# Altium schematic sheet [5]Motor_Driver.SchDoc
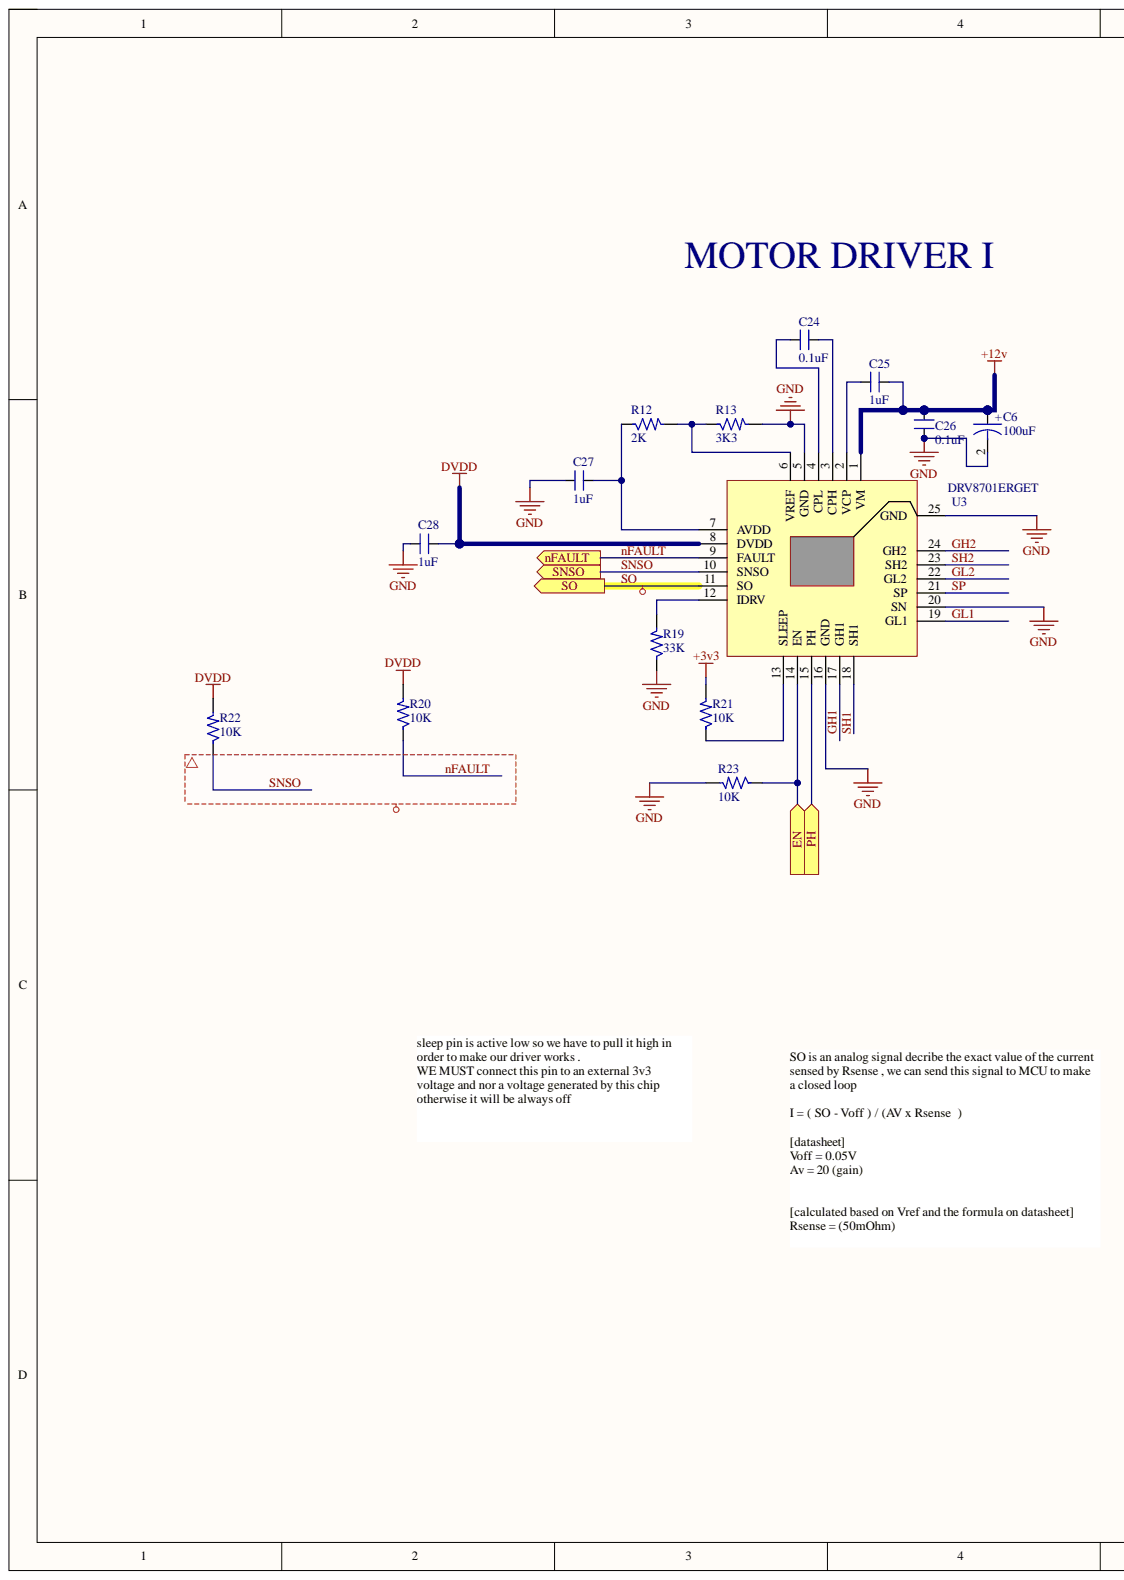
[diagram p0: [5]Motor_Driver.SchDoc, left]
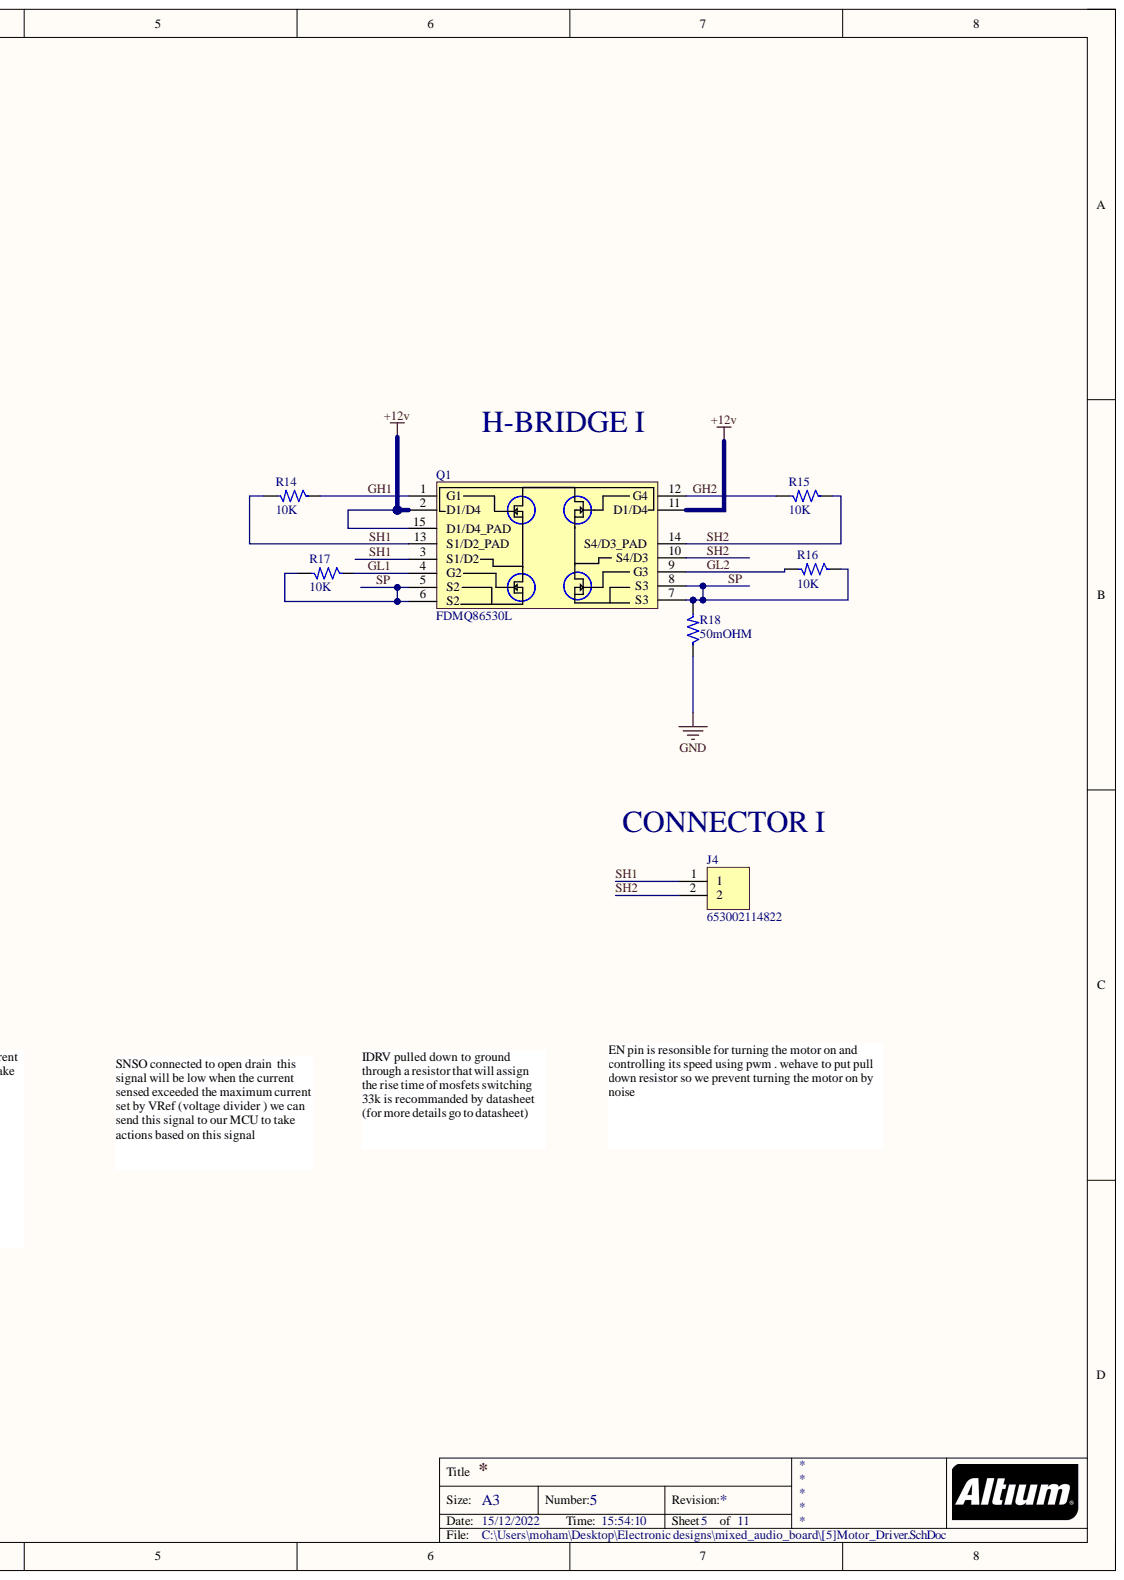
[diagram p1: [5]Motor_Driver.SchDoc, right]

## Parts
C6  100uF  [ACAP_P305_D393_H393_RAD]
C24  0.1uF  [CAP_0805]
C25  1uF  [CAP_0805]
C26  0.1uF  [CAP_0805]
C27  1uF  [CAP_0805]
C28  1uF  [CAP_0805]
J4  653002114822  [CON2_50_653002114822_TH_ST]
Q1  FDMQ86530L  [DFN5045-12_33_212_27X15_SMD_1]
R12  2K  [RES_0805]
R13  3K3  [RES_0603]
R14  10K  [RES_0805]
R15  10K  [RES_0805]
R16  10K  [RES_0805]
R17  10K  [RES_0805]
R18  50mOHM  [RES_2512]
R19  33K  [RES_0805]
R20  10K  [RES_0805]
R21  10K  [RES_0805]
R22  10K  [RES_0805]
R23  10K  [RES_0805]
U3  DRV8701ERGET  [VFQFN24_19.6_196_12X32_SMD_1]

## Ports
EN, nFAULT, PH, SNSO, SO

## Nets
+3v3: R21.2
+12v: C6.1 C25.2 C26.2 Q1.2(D1/D4) Q1.11(D1/D4) Q1.15(D1/D4_PAD) U3.1(VM)
DVDD: C28.2 R20.2 R22.2 U3.8(DVDD)
GND: C6.2 C26.1 C27.1 C28.1 R13.2 R18.1 R19.1 R23.2 U3.5(GND) U3.16(GND) U3.20(SN) U3.25(GND)
EN: R23.1 U3.14(EN) ; port EN
GH1: Q1.1(G1) R14.1 U3.17(GH1)
GH2: Q1.12(G4) R15.2 U3.24(GH2)
GL1: Q1.4(G2) R17.1 U3.19(GL1)
GL2: Q1.9(G3) R16.2 U3.22(GL2)
nFAULT: R20.1 U3.9(FAULT) ; port nFAULT
PH: U3.15(PH) ; port PH
SH1: J4.1 Q1.3(S1/D2) Q1.13(S1/D2_PAD) R14.2 U3.18(SH1)
SH2: J4.2 Q1.10(S4/D3) Q1.14(S4/D3_PAD) R15.1 U3.23(SH2)
SNSO: R22.1 U3.10(SNSO) ; port SNSO
SO: U3.11(SO) ; port SO
SP: Q1.5(S2) Q1.6(S2) Q1.7(S3) Q1.8(S3) R16.1 R17.2 R18.2 U3.21(SP)
NetC24_1: C24.1 U3.4(CPL)
NetC24_2: C24.2 U3.3(CPH)
NetC25_1: C25.1 U3.2(VCP)
NetC27_2: C27.2 R12.1 U3.7(AVDD)
NetR12_2: R12.2 R13.1 U3.6(VREF)
NetR19_2: R19.2 U3.12(IDRV)
NetR21_1: R21.1 U3.13(SLEEP)

## Library data of the parts
C6 = CAP-P_100uF-50V-20%-RAD: 100µF 50V Aluminum Polymer Capacitor Radial, Can - SMD 28mOhm 4000 Hrs @ 125°C; Alternate Part Number-1: VEU101M1HTR-1010; Manufacturer: Nichicon; Manufacturer Part Number: GYA1H101MCQ1GS; Case/Package: Radial-SMD; Component Kind: Standard; Library Name: ESTEEMPCBLIB.IntLib; Supplier Part Number-1: GYA1H101MCQ1GS; Supplier-1: SP ROAD
C24 C26 = CAP_0.1uF-50V-5%-0805: 0.1µF ±5% 50V Ceramic Capacitor X7R 0805 (2012 Metric); Alternate Part Number-1: 0805B104M500; Manufacturer: AVX Corporation; Manufacturer Part Number: 08055C104JAT4A; Case/Package: 0805; Component Kind: Standard; Library Name: ESTEEMPCBLIB.IntLib; Supplier Part Number-1: 0805B104J500CT; Supplier-1: SIRICOM
C25 C27 C28 = CAP_1uF-50V-5%-0805: 1µF ±5% 50V Ceramic Capacitor X7R 0805 (2012 Metric); Alternate Part Number-1: 0805F105M500NT; Manufacturer: Samsung; Manufacturer Part Number: CC0805JKX7R9BB105; Case/Package: 0805; Component Kind: Standard; Library Name: ESTEEMPCBLIB.IntLib; Supplier Part Number-1: CL21B105KBFNNNE; Supplier-1: SIRICOM
J4 = CON-PLG_2X1-50-ST-TH: 2 Pins, 1 Row 1.25mm Pitch, Straight Connector, male; Alternate Part Number-1: NA; Crimp Part Number: 653153128130; Manufacturer: JST; Mating Part Number: 653002113322; Case/Package: TH-CON; Component Kind: Standard; Library Name: ESTEEMPCBLIB.IntLib; Manufacturer Part Number: 653002114822; Supplier Part Number-1: 653002114822; Supplier-1: WURTH
Q1 = MOSFET-N_60V-8A-V-DFN5045-12: Mosfet Array 4 N-Channel 8A (Ta) Surface Mount V-DFN5045-12; Alternate Part Number-1: NA; Manufacturer: ON Semiconductor; Case/Package: DFN5045-12; Component Kind: Standard; Library Name: ESTEEMPCBLIB.IntLib; Manufacturer Part Number: FDMQ86530L; Supplier Part Number-1: FDMQ86530L; Supplier-1: Arrow
R12 = RES_2K-0.125W-1%-0805: 2 kOhms ±1% 0.125W, 1/8W Chip Resistor 0805 (2012 Metric) Moisture Resistant Thick Film; Alternate Part Number-1: NA; Manufacturer: TE Connectivity; Manufacturer Part Number: RC0805FR-072KL; Case/Package: 0805; Component Kind: Standard; Library Name: ESTEEMPCBLIB.IntLib; Supplier Part Number-1: WR08X2001FTL; Supplier-1: SIRICOM
R13 = RES_3.3K-0.1W-1%-0603: 3.3 kOhms ±1% 0.1W, 1/10W Chip Resistor 0603 (1608 Metric) Automotive AEC-Q200 Thick Film; Alternate Part Number-1: 0603WAF3301T5E; Manufacturer: Wilson; Manufacturer Part Number: WR06X3301FTL; Case/Package: 0603; Component Kind: Standard; Library Name: ESTEEMPCBLIB.IntLib; Supplier Part Number-1: WR06X3301FTL; Supplier-1: SIRICOM
R14-R17 R20-R23 = RES_10K-0.125W-1%-0805: 10 kOhms ±1% 0.125W, 1/8W Chip Resistor 0805 (2012 Metric) Automotive AEC-Q200 Thick Film; Alternate Part Number-1: WR08X1002FTL; Manufacturer: CTS; Case/Package: 0805; Component Kind: Standard; Library Name: ESTEEMPCBLIB.IntLib; Manufacturer Part Number: WR08X1002FTL; Supplier Part Number-1: WR08X1002FTL; Supplier-1: SIRICOM
R18 = RES_50mOhm-2W-1%-2512: 50 mOhms ±1% 2W Chip Resistor 2512 (6432 Metric) Automotive AEC-Q200, Current Sense, Moisture Resistant Metal Element; Alternate Part Number-1: PMR100HZPFU5L00; Manufacturer: Yageo; Manufacturer Part Number: PA2512FKF7W0R05E; Case/Package: 2512; Component Kind: Standard; Library Name: ESTEEMPCBLIB.IntLib; Supplier Part Number-1: LR2512-22R060F1; Supplier-1: SIRICOM
R19 = RES_33K-0.125W-1%-0805: Thick Film Resistors - SMD 33KOhms 1/8W 150V33 kOhms ±1% 0.125W, 1/8W Chip Resistor 0805 (2012 Metric) Thick Film; Alternate Part Number-1: NA; Manufacturer: Vishay BCcomponents; Manufacturer Part Number: CRG0805F33K; Case/Package: 0805; Component Kind: Standard; Library Name: ESTEEMPCBLIB.IntLib; Supplier Part Number-1: WR08X3302FTL; Supplier-1: SIRICOM
U3 = IC_DRV8701ERGET: Motor Driver Power MOSFET Parallel 24-VQFN (4x4); Alternate Part Number-1: NA; Manufacturer: Texas Instruments; Case/Package: VFQFN24; Component Kind: Standard; Library Name: ESTEEMPCBLIB.IntLib; Manufacturer Part Number: DRV8701ERGET; Supplier Part Number-1: DRV8701ERGET; Supplier-1: ARROW
